# Altium schematic sheet main_power_switch.SchDoc
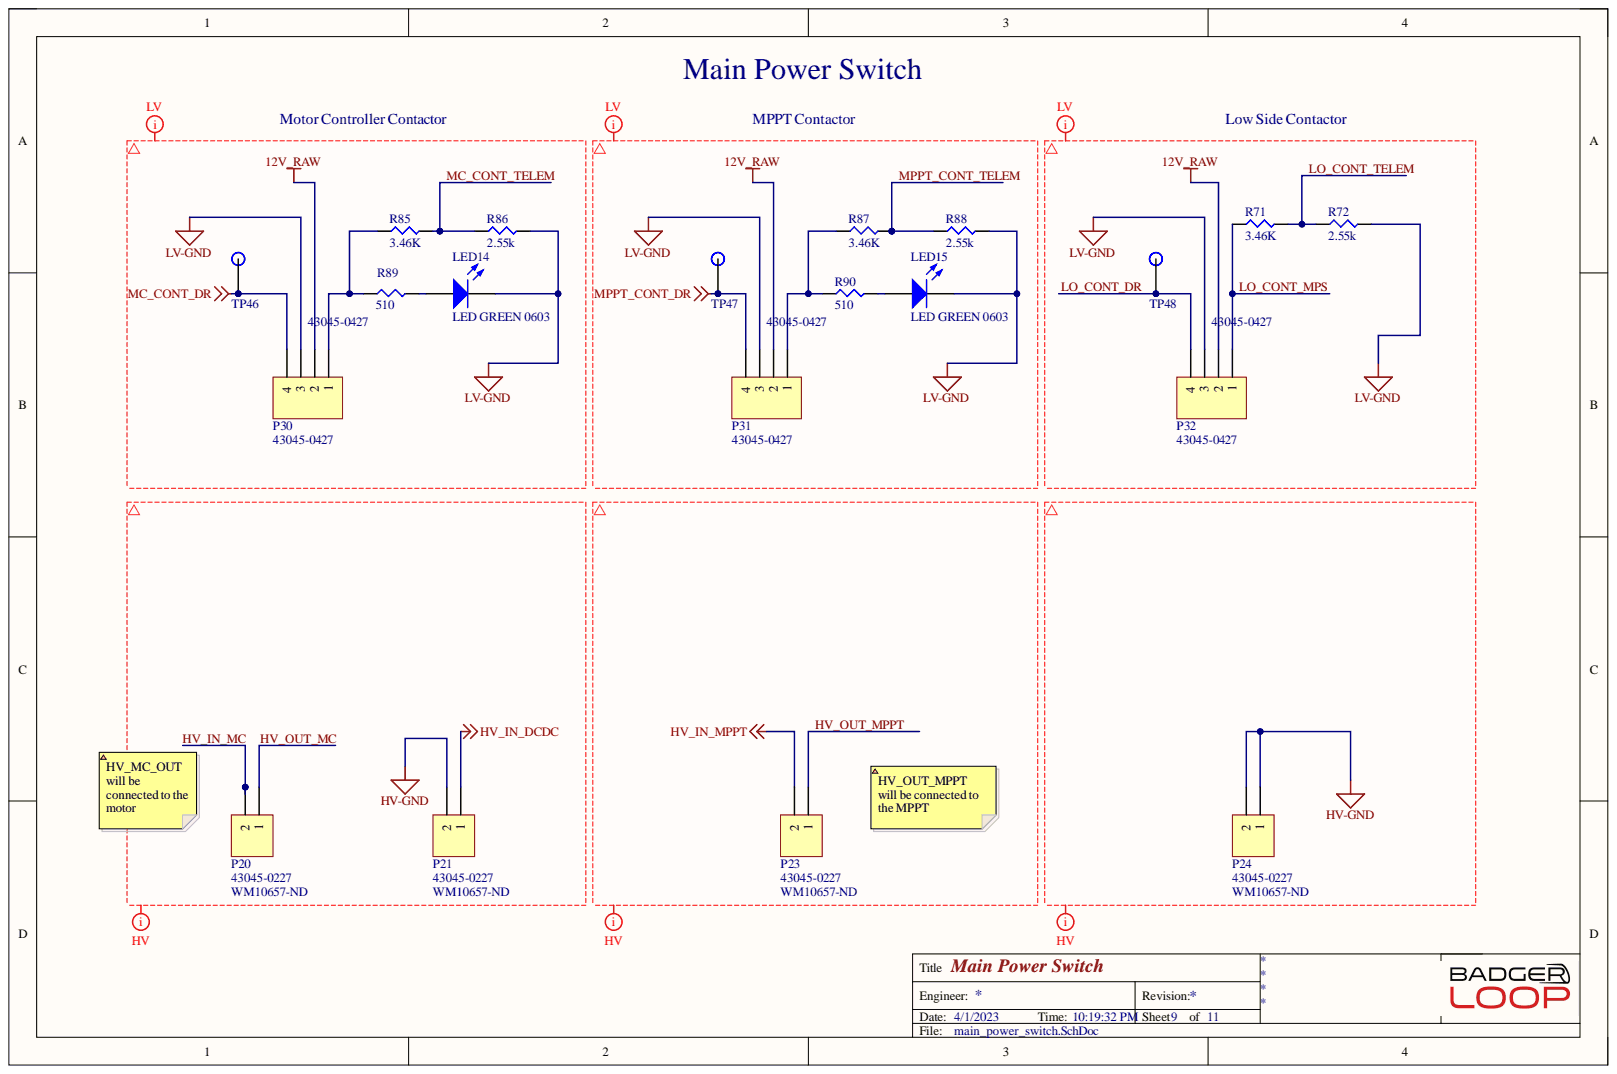
[diagram p0: main_power_switch.SchDoc]

## Parts
LED14  LED GREEN 0603  Color: GREEN  [LED 0603 GREEN]
LED15  LED GREEN 0603  Color: GREEN  [LED 0603 GREEN]
P20  43045-0227  [43045-0277]
P21  43045-0227  [43045-0277]
P23  43045-0227  [43045-0277]
P24  43045-0227  [43045-0277]
P30  43045-0427  [430450427]
P31  43045-0427  [430450427]
P32  43045-0427  [430450427]
R71  3.46K  [SMD-0805-RES]
R72  2.55k  [SMD-1206-RES]
R85  3.46K  [SMD-0805-RES]
R86  2.55k  [SMD-1206-RES]
R87  3.46K  [SMD-0805-RES]
R88  2.55k  [SMD-1206-RES]
R89  RMCF1206FT510R  [SMD-1206-RES]  (value not drawn)
R90  RMCF1206FT510R  [SMD-1206-RES]  (value not drawn)
TP46  TP  [Test Point]
TP47  TP  [Test Point]
TP48  TP  [Test Point]

## Nets
12V_RAW: P30.2 P31.2 P32.2
HV-GND: P21.2 P24.1 P24.2
HV_IN_DCDC: P21.1
HV_IN_MPPT: P23.2
LV-GND: LED14.2 LED15.2 P30.3 P31.3 P32.3 R72.2 R86.2 R88.2
MC_CONT_DR: P30.4 TP46.1
MPPT_CONT_DR: P31.4 TP47.1
HV_IN_MC: P20.2
HV_OUT_MC: P20.1
HV_OUT_MPPT: P23.1
LO_CONT_DR: P32.4 TP48.1
LO_CONT_MPS: P32.1 R71.1
LO_CONT_TELEM: R71.2 R72.1
MC_CONT_TELEM: R85.2 R86.1
MPPT_CONT_TELEM: R87.2 R88.1
NetLED14_1: LED14.1 R89.2
NetLED15_1: LED15.1 R90.2
NetP30_1: P30.1 R85.1 R89.1
NetP31_1: P31.1 R87.1 R90.1

## Library data of the parts
LED14 LED15 = LED GREEN 0603: Manufacturer: Lite-On Inc.; Manufacturer P/N: LTST-C190KGKT; Component Kind: Standard; Digikey P/N: 160-1435-1-ND; Library Name: misc.SchLib; Package / Case: 0603
P20 P21 P23 P24 = 43045-0227: Board Connector, 2 Contact(s), 2 Row(s), Male, Straight, Solder Terminal, Locking, Black Insulator, Receptacle; Manufacturer: Molex; Board Mounting Option: PEG; Body Breadth: 0.29inch; Body Depth: 0.39inch; Body Length: 0.262inch; Contact Resistance: 10mR; Contact Style: SQ PIN-SKT; DIN Conformance: No; Insertion Force-Max: 8.0064N; Insulation Resistance: 1GR; Mating Information: MULTIPLE MATING PARTS AVAILABLE; Number Of Connectors: 1; Number of Contacts: 2; Option: General Purpose; Plating Thickness: 6uin; Rated Current (Signal): 2.5A; Reference Standard: UL; Reliability: COMMERCIAL; Throw Configuration: S0P2; Withdrawl Force-Min: 3.5584N; Body/Shell Style: RECEPTACLE; Category: Headers and Wire Housings; Component Kind: Standard; ComponentLink1Description: https://datasheet.ciiva.com:443/pdfs/VipMasterIC/IC/MOLE/MOLE-S-A0001360181/MOLE-S-A0001399497-1.pdf?src-supplier=IHS+Markit; ComponentLink1URL: https://datasheet.ciiva.com:443/pdfs/VipMasterIC/IC/MOLE/MOLE-S-A0001360181/MOLE-S-A0001399497-1.pdf?src-supplier=IHS+Markit; ComponentLink2Description: https://datasheet.ciiva.com/5148/0430450227-pcb-headers-142611-5148694.pdf?src-supplier=Mouser; ComponentLink2URL: https://datasheet.ciiva.com/5148/0430450227-pcb-headers-142611-5148694.pdf?src-supplier=Mouser; ComponentLink3Description: https://datasheet.ciiva.com/16103/307170430450227-pcb-headers-16103122.pdf?src-supplier=Onlinecomponents.com; ComponentLink3URL: https://datasheet.ciiva.com/16103/307170430450227-pcb-headers-16103122.pdf?src-supplier=Onlinecomponents.com; ComponentLink4Description: https://datasheet.ciiva.com/11752/0900766b80d4a80b-11752890.pdf?src-supplier=RSComponents; ComponentLink4URL: https://datasheet.ciiva.com/11752/0900766b80d4a80b-11752890.pdf?src-supplier=RSComponents; ComponentLink5Description: https://datasheet.ciiva.com/30256/212010430450227-pcb-headers-30256881.pdf?src-supplier=Onlinecomponents.com; ComponentLink5URL: https://datasheet.ciiva.com/30256/212010430450227-pcb-headers-30256881.pdf?src-supplier=Onlinecomponents.com; ComponentLink6Description: https://datasheet.ciiva.com/16725/0430450227-pcb-headers-16725483.pdf?src-supplier=Avnet+Europe; ComponentLink6URL: https://datasheet.ciiva.com/16725/0430450227-pcb-headers-16725483.pdf?src-supplier=Avnet+Europe; ComponentLink7Description: https://datasheet.ciiva.com/10089/0430450227-pcb-headers-10089453.pdf?src-supplier=Avnet; ComponentLink7URL: https://datasheet.ciiva.com/10089/0430450227-pcb-headers-10089453.pdf?src-supplier=Avnet; Connector Type: BOARD CONNECTOR; Contact Finish - Mating: TIN (100) OVER NICKEL; Contact Finish - Termination: TIN (100) OVER NICKEL; Contact Gender: Male; Contact Material: Brass; Filter Feature: No; Flammability Rating: UL94 V-0; IEC Conformance: No; Insulation Color: Black; Insulation Material: Polymer; JESD-609 Code: e3; Library Name: connector.SchLib; Manufacturer Part Number: 43045-0227; Max Operating Temperature: 105°C; MIL Conformance: No; Min Operating Temperature: -40°C; Mixed Contacts: No; Mount: Board; Mounting Option 1: LOCKING; Mounting Style: STRAIGHT; Number of Rows Loaded: 2; Pricing 1: 1=0.97000, 10=0.80600, 80=0.67200, 945=0.53760, 2400=0.48720 (USD); REACH SVHC: Yes; RoHS: Yes; RoHS Compliant: Yes; Stock 1: 12970; Supplier 1: Digi-Key; Supplier Part Number 1: WM10657-ND; Terminal Length: 0.125inch; Terminal Pitch: 3mm; Termination: Solder
P30-P32 = 43045-0427: Board Connector, 4 Contact(s), 2 Row(s), Male, Straight, Solder Terminal, Locking, Black Insulator, Receptacle; Manufacturer: Molex; Board Mounting Option: PEG; Body Breadth: 0.29inch; Body Depth: 0.39inch; Body Length: 0.38inch; Contact Resistance: 10mR; Contact Style: SQ PIN-SKT; DIN Conformance: No; Insertion Force-Max: 8.0064N; Insulation Resistance: 1GR; Mating Information: MULTIPLE MATING PARTS AVAILABLE; Number Of Connectors: 1; Number of Contacts: 4; Number of Rows Loaded: 2; Option: General Purpose; Plating Thickness: 6uin; Rated Current (Signal): 2.5A; Reference Standard: UL; Reliability: COMMERCIAL; Throw Configuration: S0P4; Withdrawl Force-Min: 3.5584N; Body/Shell Style: RECEPTACLE; Category: Headers and Wire Housings; Component Kind: Standard; ComponentLink1Description: https://datasheet.ciiva.com:443/pdfs/VipMasterIC/IC/MOLE/MOLE-S-A0001360181/MOLE-S-A0001399497-1.pdf?src-supplier=IHS+Markit; ComponentLink1URL: https://datasheet.ciiva.com:443/pdfs/VipMasterIC/IC/MOLE/MOLE-S-A0001360181/MOLE-S-A0001399497-1.pdf?src-supplier=IHS+Markit; ComponentLink2Description: https://datasheet.ciiva.com/5148/0430450427-pcb-headers-142988-5148953.pdf?src-supplier=Mouser; ComponentLink2URL: https://datasheet.ciiva.com/5148/0430450427-pcb-headers-142988-5148953.pdf?src-supplier=Mouser; ComponentLink3Description: https://datasheet.ciiva.com/11748/0900766b80d4a80b-11748554.pdf?src-supplier=RSComponents; ComponentLink3URL: https://datasheet.ciiva.com/11748/0900766b80d4a80b-11748554.pdf?src-supplier=RSComponents; ComponentLink4Description: https://datasheet.ciiva.com/30143/176090430450427-pcb-headers-30143652.pdf?src-supplier=Onlinecomponents.com; ComponentLink4URL: https://datasheet.ciiva.com/30143/176090430450427-pcb-headers-30143652.pdf?src-supplier=Onlinecomponents.com; ComponentLink5Description: https://datasheet.ciiva.com/16725/0430450427-pcb-headers-16725499.pdf?src-supplier=Avnet+Europe; ComponentLink5URL: https://datasheet.ciiva.com/16725/0430450427-pcb-headers-16725499.pdf?src-supplier=Avnet+Europe; ComponentLink6Description: https://datasheet.ciiva.com/10088/0430450427-pcb-headers-10088767.pdf?src-supplier=Avnet; ComponentLink6URL: https://datasheet.ciiva.com/10088/0430450427-pcb-headers-10088767.pdf?src-supplier=Avnet; Connector Type: BOARD CONNECTOR; Contact Finish - Mating: TIN (100) OVER NICKEL; Contact Finish - Termination: TIN (100) OVER NICKEL; Contact Gender: Male; Contact Material: Brass; Filter Feature: No; Flammability Rating: UL94 V-0; IEC Conformance: No; Insulation Color: Black; Insulation Material: Polymer; JESD-609 Code: e3; Library Name: connector.SchLib; Manufacturer Part Number: 43045-0427; Max Operating Temperature: 105°C; MIL Conformance: No; Min Operating Temperature: -40°C; Mixed Contacts: No; Mount: Board; Mounting Option 1: LOCKING; Mounting Style: STRAIGHT; Pricing 1: 1=1.45000, 10=1.23200, 80=1.01750, 2232=0.77647, 4464=0.74970 (USD); REACH SVHC: Yes; RoHS: Yes; RoHS Compliant: Yes; Stock 1: 18088; Supplier 1: Digi-Key; Supplier Part Number 1: WM10667-ND; Terminal Length: 0.125inch; Terminal Pitch: 3mm; Termination: Solder
R71 R85 R87 = CRCW08053K46FKTA: Fixed Resistor, Metal Glaze/thick Film, 0.125W, 3460ohm, 150V, 1% +/-Tol, 100ppm/Cel, Surface Mount, 0805; Manufacturer: Vishay Dale; Category: Chip SMD Resistors; Component Kind: Standard; ComponentLink1Description: https://datasheet.ciiva.com:443/pdfs/VipMasterIC/IC/VISH/VISHS12541/VISHS12541-1.pdf?src-supplier=IHS+Markit; ComponentLink1URL: https://datasheet.ciiva.com:443/pdfs/VipMasterIC/IC/VISH/VISHS12541/VISHS12541-1.pdf?src-supplier=IHS+Markit; ComponentLink2Description: http://www.vishay.com/docs/20008/dcrcw.pdf?src-supplier=Digi-Key; ComponentLink2URL: http://www.vishay.com/docs/20008/dcrcw.pdf?src-supplier=Digi-Key; ComponentLink3Description: http://www.vishay.com/doc?20008?src-supplier=Mouser; ComponentLink3URL: http://www.vishay.com/doc?20008?src-supplier=Mouser; Library Name: resistors.SchLib; Manufacturer Part Number: CRCW08053K46FKTA; Number of Pins: 2; Pricing 1: 1=0.17000, 10=0.13500, 50=0.13500, 100=0.05200, 1000=0.02300, 10000=0.01900 (USD); REACH SVHC: No SVHC; Resistor Type: FIXED RESISTOR; RoHS: No; RoHS Compliant: No; Stock 1: 3820; Supplier 1: Mouser; Supplier Part Number 1: 71-CRCW0805-3.46K
R72 R86 R88 = ERJ-8ENF2551V: RES SMD 2.55K OHM 1% 1/4W 1206; Manufacturer: Panasonic Electronic Components; @ qty: 1500; Factory Stock: 0; Minimum Quantity: 1; Power (Watts): 0.25W, 1/4W; Temperature Coefficient: ±100ppm/°C; Unit Price (USD): 0.00933; Component Kind: Standard; Composition: Thick Film; Datasheets: http://industrial.panasonic.com/www-cgi/jvcr13pz.cgi?E+PZ+3+AOA0002+ERJ8ENF2551V+7+WW; Digi-Key Part Number: P2.55KFCT-ND; Failure Rate: -; Features: Automotive AEC-Q200; Height - Seated (Max): 0.028" (0.70mm); Image: //media.digikey.com/Renders/Panasonic%20Renders/ERJ-1206%20Pkg.jpg; Library Name: resistors.SchLib; Number of Terminations: 2; Operating Temperature: -55°C ~ 155°C; Package / Case: 1206 (3216 Metric); Packaging: Cut Tape (CT); Part Number: ERJ-8ENF2551V; Part Status: Active; Quantity Available: 30143; Series: ERJ; Size / Dimension: 0.126" L x 0.063" W (3.20mm x 1.60mm); Supplier Device Package: 1206; Tolerance: ±1%; Value: 2.55k
R89 R90 = RMCF1206FT510R: Fixed Resistor, Metal Glaze/thick Film, 0.25W, 510ohm, 200V, 1% +/-Tol, 100ppm/Cel, Surface Mount, 1206; Manufacturer: Stackpole Electronics; Package Height: 0.55mm; Package Length: 3.2mm; Package Width: 1.6mm; Rated Power Dissipation (P): 250mW; Rated Temperature: 70°C; Reference Standard: AEC-Q200; Resistance: 510; Temperature Coefficient: 100ppm/°C; Category: Chip SMD Resistors; Component Kind: Standard; ComponentLink1Description: https://datasheet.ciiva.com:443/pdfs/VipMasterIC/IC/SEIE/SEIE-S-A0009541969/SEIE-S-A0009541969-1.pdf?src-supplier=IHS+Markit; ComponentLink1URL: https://datasheet.ciiva.com:443/pdfs/VipMasterIC/IC/SEIE/SEIE-S-A0009541969/SEIE-S-A0009541969-1.pdf?src-supplier=IHS+Markit; ComponentLink2Description: http://components.arrow.com/part/dataview/40948710S9551678N1174?src-supplier=Arrow+Electronics; ComponentLink2URL: http://components.arrow.com/part/dataview/40948710S9551678N1174?src-supplier=Arrow+Electronics; ComponentLink3Description: https://www.seielect.com/Catalog/SEI-RMCF_RMCP.pdf?src-supplier=Digi-Key; ComponentLink3URL: https://www.seielect.com/Catalog/SEI-RMCF_RMCP.pdf?src-supplier=Digi-Key; ComponentLink4Description: https://www.seielect.com/catalog/SEI-Packaging.pdf?src-supplier=Digi-Key; ComponentLink4URL: https://www.seielect.com/catalog/SEI-Packaging.pdf?src-supplier=Digi-Key; ComponentLink5Description: https://www.seielect.com/catalog/SEI-RMCF_RMCP.pdf?src-supplier=Avnet; ComponentLink5URL: https://www.seielect.com/catalog/SEI-RMCF_RMCP.pdf?src-supplier=Avnet; Construction: Rectangular; JESD-609 Code: e3; Library Name: resistors.SchLib; Manufacturer Part Number: RMCF1206FT510R; Max Operating Temperature: 155°C; Min Operating Temperature: -55°C; Mount: Surface Mount; Number of Pins: 2; Package Shape: Rectangular; Package Style: SMTMeter; Packaging: Tape and Reel; Pricing 1: 1=0.10000, 10=0.03600, 100=0.01460, 1000=0.00655, 2500=0.00569 (USD); REACH SVHC: Yes; Resistor Type: FIXED RESISTOR; RoHS: Yes; RoHS Compliant: Yes; Size Code: 1206; Stock 1: 51; Supplier 1: Digi-Key; Supplier Part Number 1: RMCF1206FT510RCT-ND; Technology: METAL GLAZE/THICK FILM; Terminal Finish: Nickel; Termination: Wraparound; Tolerance: 1%; Working Voltage: 200V
TP46-TP48 = TP: SMD TEST POINT; Component Kind: Standard; Library Name: misc.SchLib
